# Altium schematic sheet wifi_BT.SchDoc(wifi_BT)
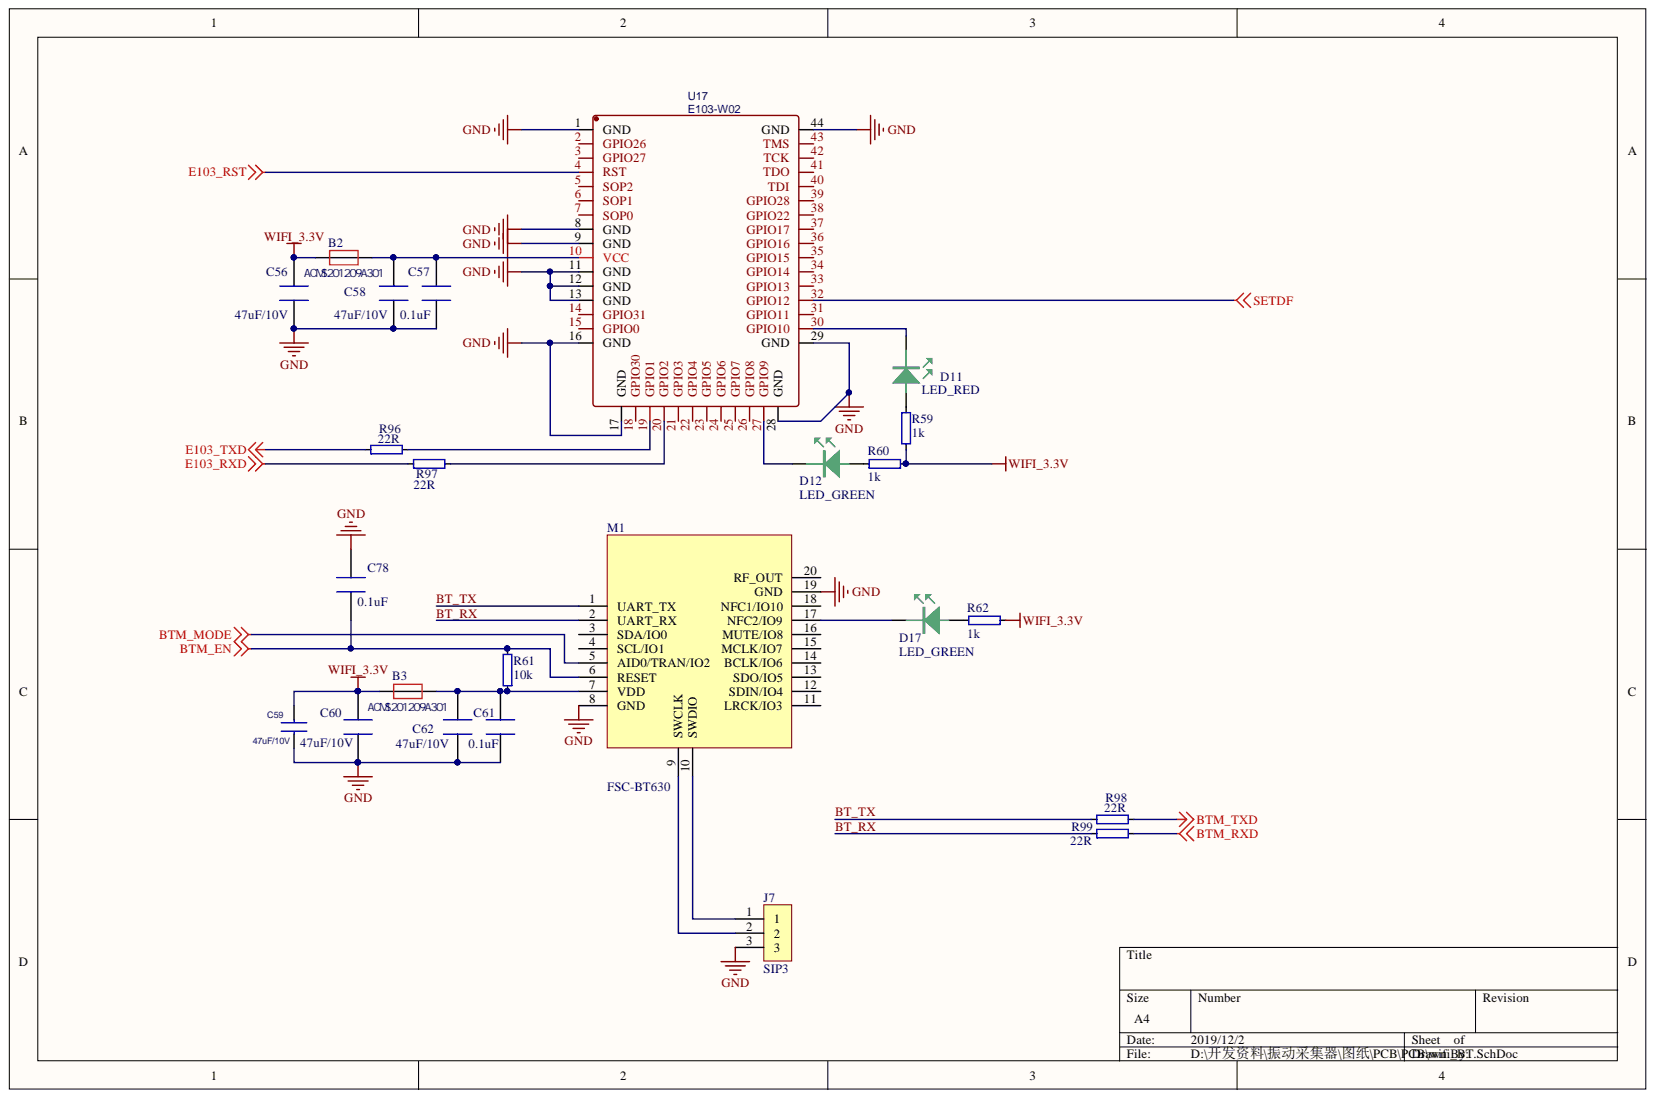
[diagram p0: wifi_BT.SchDoc]

## Parts
B2  ACMS201209A301  [0805_R]
B3  ACMS201209A301  [0805_R]
C56  47uF/10V  [0805_C]
C57  0.1uF  [0402_C]
C58  47uF/10V  [0805_C]
C59  47uF/10V  [0805_C]
C60  47uF/10V  [0805_C]
C61  0.1uF  [0402_C]
C62  47uF/10V  [0805_C]
C78  0.1uF  [0402_C]
D11  LED_RED  [0603_LED_S1]
D12  LED_GREEN  [0603_LED_S1]
D17  LED_GREEN  [0603_LED_S1]
J7  SIP3  [SIP3]
M1  FSC-BT630  [FSC-BT630]
R59  1k  [0402]
R60  1k  [0402]
R61  10k  [0402]
R62  1k  [0402]
R96  22R  [0402]
R97  22R  [0402]
R98  22R  [0402]
R99  22R  [0402]
U17  E103-W02  [E103-W02]

## Nets
BTM_EN: C78.1 M1.6(RESET) R61.1
BTM_MODE: M1.5(AID0/TRAN/IO2)
BTM_RXD: R99.1
BTM_TXD: R98.1
E103_RST: U17.4(RST)
E103_RXD: R97.2
E103_TXD: R96.2
GND: C56.2 C57.2 C58.2 C59.2 C60.2 C61.2 C62.2 C78.2 J7.3 M1.8(GND) M1.19(GND) U17.1(GND) U17.8(GND) U17.9(GND) U17.11(GND) U17.12(GND) U17.13(GND) U17.16(GND) U17.17(GND) U17.28(GND) U17.29(GND) U17.44(GND)
SETDF: U17.32(GPIO12)
WIFI_3.3V: B2.1 B3.1 C56.1 C59.1 C60.1 R59.1 R60.1 R62.1
BT_RX: M1.2(UART_RX) R99.2
BT_TX: M1.1(UART_TX) R98.2
NetB2_2: B2.2 C57.1 C58.1 U17.10(VCC)
NetB3_2: B3.2 C61.1 C62.1 M1.7(VDD) R61.2
NetD11_A: D11.A R59.2
NetD11_K: D11.K U17.30(GPIO10)
NetD12_A: D12.A R60.2
NetD12_K: D12.K U17.27(GPIO9)
NetD17_A: D17.A R62.2
NetD17_K: D17.K M1.17(NFC2/IO9)
NetJ7_1: J7.1 M1.10(SWDIO)
NetJ7_2: J7.2 M1.9(SWCLK)
NetR96_1: R96.1 U17.19(GPIO1)
NetR97_1: R97.1 U17.20(GPIO2)

Not connected: M1 3(SDA/IO0) 4(SCL/IO1) 11(LRCK/IO3) 12(SDIN/IO4) 13(SDO/IO5) 14(BCLK/IO6) 15(MCLK/IO7) 16(MUTE/IO8) 18(NFC1/IO10) 20(RF_OUT); U17 2(GPIO26) 3(GPIO27) 5(SOP2) 6(SOP1) 7(SOP0) 14(GPIO31) 15(GPIO0) 18(GPIO30) 21(GPIO3) 22(GPIO4) 23(GPIO5) 24(GPIO6) 25(GPIO7) 26(GPIO8) 31(GPIO11) 33(GPIO13) 34(GPIO14) 35(GPIO15) 36(GPIO16) 37(GPIO17) 38(GPIO22) 39(GPIO28) 40(TDI) 41(TDO) 42(TCK) 43(TMS)

## Library data of the parts
B2 B3 = Bead: Component Kind: Standard; Library Name: RP3288BV03_20180126-.SCHLIB
C56 C58 C60 C62 = STD-06-0048: CHIP CAPACITOR, CERAMIC, 100NF, 16V, 10%, X7R, 0402; Manufacturer: Yageo; Manufacturer Part Number: CC0402KRX7R7BB104; Approx Volume Cost ($): 0.003; Database Table Name: MLCC$; VALUE: 100N; Component Kind: Standard; Dielectric: X7R; Part Number: STD-06-0048; Rated Voltage: 16V; Tolerance: 10%; Library Name: JG_StandardLibrary.DbLib
C57 C61 C78 = STD-06-0048: CHIP CAPACITOR, CERAMIC, 100NF, 16V, 10%, X7R, 0402; Manufacturer: Yageo; Manufacturer Part Number: CC0402KRX7R7BB104; Approx Volume Cost ($): 0.003; Database Table Name: MLCC$; Component Kind: Standard; Dielectric: X7R; Part Number: STD-06-0048; Rated Voltage: 16V; Tolerance: 10%; VALUE: 0.1uF; Library Name: JG_StandardLibrary.DbLib
C59 = CAP NP_Dup4: RoHS CHIP CAP 4.7uF +10/-10% X5R; Component Kind: Standard
D11 D12 D17 = LED_0603_GREEN: MANUFACTURER: KINGBRIGHT; PART: KP-3216CGCK; Component Kind: Standard; Description: LED_0603_GREEN; FARNELL: 2290333; Library Name: Arbury_SCH.SchLib
R59-R62 R96-R99 = R0402-12k: Resistor, ?%, 0.063W; Artikkelnr Noca: 27049099; Database Table Name: Resistors$; Note: Chip Res 1%; Value: 12k; Component Kind: Standard; LatestRevisionDate: 2009-11-23; Library Name: Nordic Passive DB.DbLib; Part Number: R0402-12k; Pin Count: 2; Published: 2009-05-08; Supplier: Farnell; Supplier part number: 173-8865; Publisher: Nordic Semiconductor
U17 = E103-W02: BOM_Manufacturer: Chengdu Ebyte Elec Tech; Contributor: LCSC; BOM_Manufacturer Part: E103-W02; BOM_Supplier: LCSC; BOM_Supplier Part: C108558; Component Kind: Standard; spicePre: U
J7 = SIP3: Component Kind: Standard; Library Name: PCB_Project.SCHLIB
M1 = FSC-BT630: Component Kind: Standard; Library Name: 振动采集器.SCHLIB
